# Altium schematic sheet LTC3811AM.SchDoc(LTC3811AM)
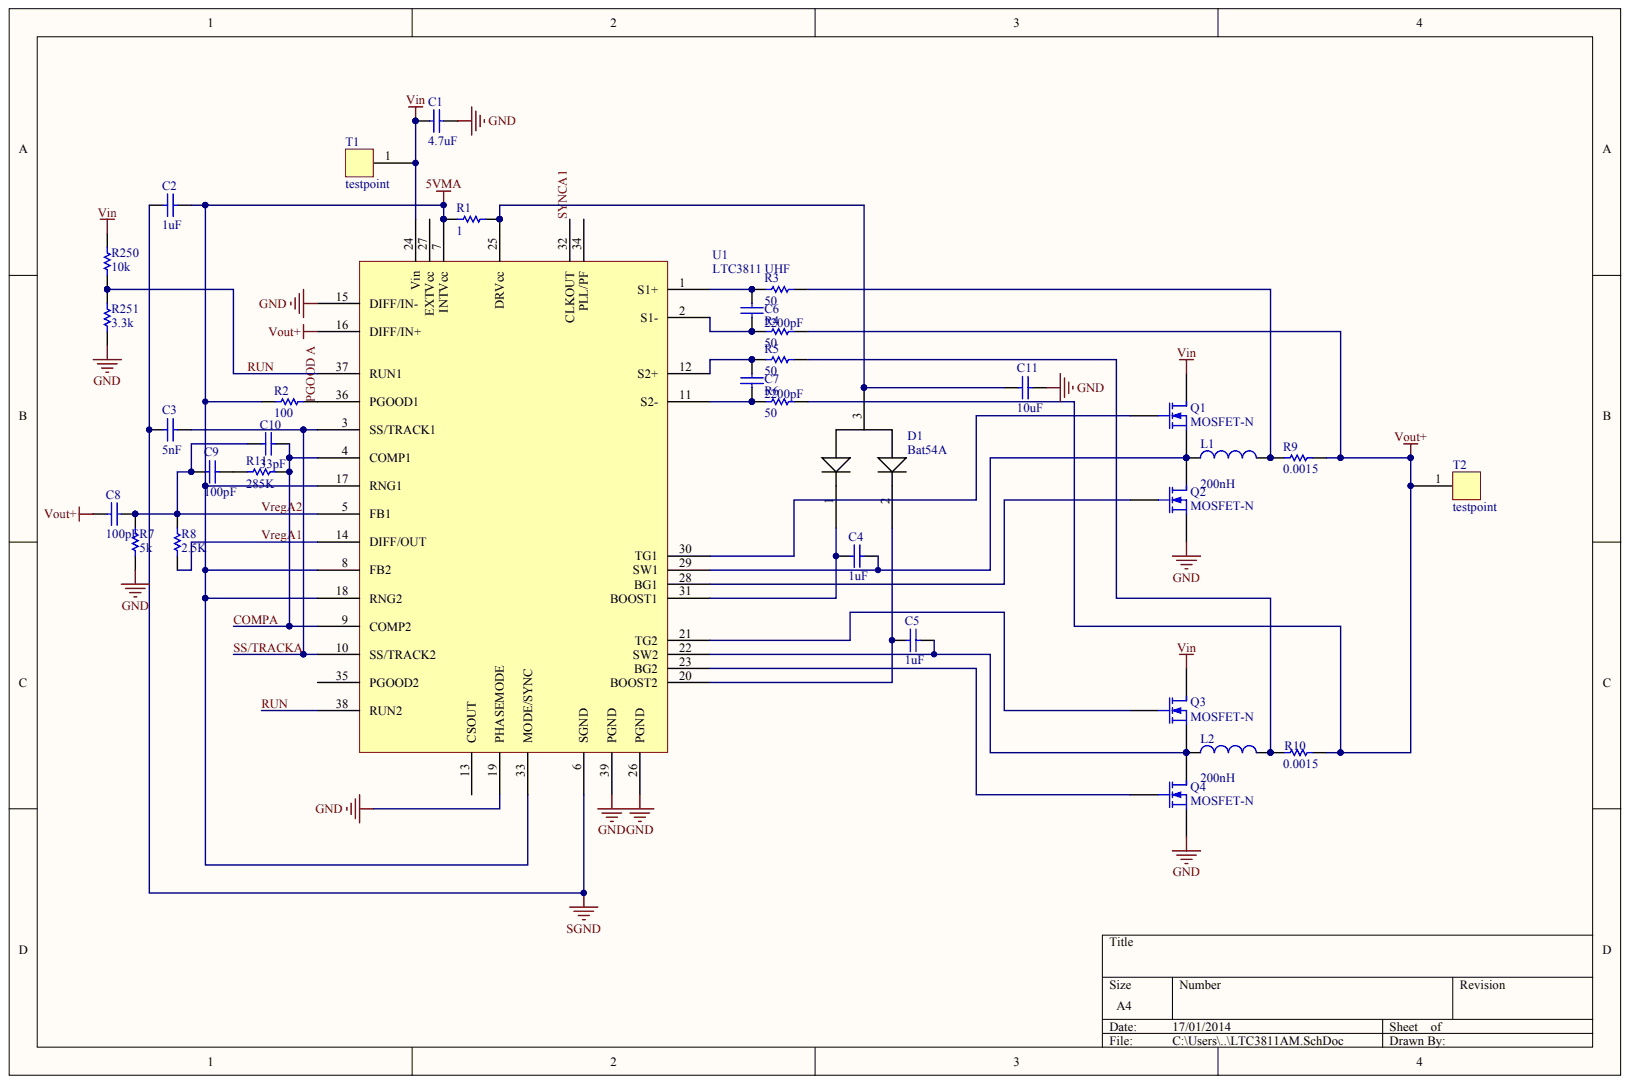
[diagram p0: LTC3811AM.SchDoc]

## Parts
C1  4.7uF
C2  1uF
C3  5nF
C4  1uF
C5  1uF
C6  2200pF
C7  2200pF
C8  100pF
C9  100pF
C10  33pF
C11  10uF
D1  Bat54A
L1  value 200nH
L2  value 200nH
Q1  MOSFET-N
Q2  MOSFET-N
Q3  MOSFET-N
Q4  MOSFET-N
R1  1
R2  100
R3  50
R4  50
R5  50
R6  50
R7  5k
R8  2.5K
R9  0.0015
R10  0.0015
R11  285K
R250  10k
R251  3.3k
T1  testpoint
T2  testpoint
U1  LTC3811 UHF

## Nets
5VMA: C2.1 R1.1 R2.1 U1.7(INTVcc) U1.8(FB2) U1.17(RNG1) U1.18(RNG2) U1.33(MODE/SYNC)
GND: C1.1 C11.2 Q2.3 Q4.3 R7.1 R251.1 U1.15(DIFF/IN-) U1.19(PHASEMODE) U1.26(PGND) U1.39(PGND)
SGND: C2.2 C3.2 U1.6(SGND)
VIN: C1.2 Q1.1 Q3.1 R250.2 T1.1 U1.24(Vin)
VOUT+: C8.2 R4.2 R6.2 R9.1 R10.1 T2.1 U1.16(DIFF/IN+)
COMPA: C10.1 R11.1 U1.4(COMP1) U1.9(COMP2)
PGOOD A: R2.2 U1.36(PGOOD1)
RUN: R250.1 R251.2 U1.37(RUN1) U1.38(RUN2)
SS/TRACKA: C3.1 U1.3(SS/TRACK1) U1.10(SS/TRACK2)
SYNCA1: U1.32(CLKOUT)
VREGA1: R8.1 U1.14(DIFF/OUT)
VREGA2: C8.1 C9.2 C10.2 R7.2 R8.2 U1.5(FB1)
NetC4_1: C4.1 D1.1 U1.31(BOOST1)
NetC4_2: C4.2 L1.1 Q1.3 Q2.1 U1.29(SW1)
NetC5_1: C5.1 L2.1 Q3.3 Q4.1 U1.22(SW2)
NetC5_2: C5.2 D1.2 U1.20(BOOST2)
NetC6_1: C6.1 R3.1 U1.1(S1+)
NetC6_2: C6.2 R4.1 U1.2(S1-)
NetC7_1: C7.1 R5.1 U1.12(S2+)
NetC7_2: C7.2 R6.1 U1.11(S2-)
NetC9_1: C9.1 R11.2
NetC11_1: C11.1 D1.3 R1.2 U1.25(DRVcc)
NetL1_2: L1.2 R3.2 R9.2
NetL2_2: L2.2 R5.2 R10.2
NetQ1_2: Q1.2 U1.30(TG1)
NetQ2_2: Q2.2 U1.28(BG1)
NetQ3_2: Q3.2 U1.21(TG2)
NetQ4_2: Q4.2 U1.23(BG2)

Not connected: U1 13(CSOUT) 27(EXTVcc) 34(PLL/PF) 35(PGOOD2)

## Library data of the parts
L1 L2 = (no symbol name): Code_IEC: 1005; Value: 200nH; Published: 8-Jun-2000; LatestRevisionDate: 29-May-2009; LatestRevisionNote: IPC-7351 Footprint Added.; PackageReference: 0402-A; PackageDescription: Chip Inductor; Code_JEITA: 0402; Publisher: Altium Limited
C1-C11 D1 Q1-Q4 R1-R11 R250 R251 T1 T2 U1 = (no symbol name)
  C1: Published: 8-Jun-2000; LatestRevisionDate: 17-Jul-2002; LatestRevisionNote: Re-released for DXP Platform.; PackageReference: RAD-0.3; PackageDescription: Radial Cap, Thru-Hole; 2 Leads; 0.3 in Pin Spacing; Value: 4.7uF
  C2 C4 C5: Published: 8-Jun-2000; LatestRevisionDate: 17-Jul-2002; LatestRevisionNote: Re-released for DXP Platform.; PackageReference: RAD-0.3; PackageDescription: Radial Cap, Thru-Hole; 2 Leads; 0.3 in Pin Spacing; Value: 1uF
  C3: Published: 8-Jun-2000; LatestRevisionDate: 17-Jul-2002; LatestRevisionNote: Re-released for DXP Platform.; PackageReference: RAD-0.3; PackageDescription: Radial Cap, Thru-Hole; 2 Leads; 0.3 in Pin Spacing; Value: 5nF
  C6 C7: Published: 8-Jun-2000; LatestRevisionDate: 17-Jul-2002; LatestRevisionNote: Re-released for DXP Platform.; PackageReference: RAD-0.3; PackageDescription: Radial Cap, Thru-Hole; 2 Leads; 0.3 in Pin Spacing; Value: 2200pF
  C8 C9: Published: 8-Jun-2000; LatestRevisionDate: 17-Jul-2002; LatestRevisionNote: Re-released for DXP Platform.; PackageReference: RAD-0.3; PackageDescription: Radial Cap, Thru-Hole; 2 Leads; 0.3 in Pin Spacing; Value: 100pF
  C10: Published: 8-Jun-2000; LatestRevisionDate: 17-Jul-2002; LatestRevisionNote: Re-released for DXP Platform.; PackageReference: RAD-0.3; PackageDescription: Radial Cap, Thru-Hole; 2 Leads; 0.3 in Pin Spacing; Value: 33pF
  C11: Published: 8-Jun-2000; LatestRevisionDate: 17-Jul-2002; LatestRevisionNote: Re-released for DXP Platform.; PackageReference: RAD-0.3; PackageDescription: Radial Cap, Thru-Hole; 2 Leads; 0.3 in Pin Spacing; Value: 10uF
  Q1-Q4: Published: 8-Jun-2000; LatestRevisionDate: 17-Jul-2002; LatestRevisionNote: Re-released for DXP Platform.; PackageVersion: 1995/1996; PackageReference: E3; PackageDescription: TO, Flat Index; 3 In-Line, Axial Leads; Body Dia. 4.6mm; Lead Dia. 0.5 mm (max)
  R1: Published: 8-Jun-2000; LatestRevisionDate: 17-Jul-2002; LatestRevisionNote: Re-released for DXP Platform.; PackageReference: AXIAL-0.3; PackageDescription: Axial Device, Thru-Hole; 2 Leads; 0.3 in Pin Spacing; Value: 1
  R2: Published: 8-Jun-2000; LatestRevisionDate: 17-Jul-2002; LatestRevisionNote: Re-released for DXP Platform.; PackageReference: AXIAL-0.3; PackageDescription: Axial Device, Thru-Hole; 2 Leads; 0.3 in Pin Spacing; Value: 100
  R3-R6: Published: 8-Jun-2000; LatestRevisionDate: 17-Jul-2002; LatestRevisionNote: Re-released for DXP Platform.; PackageReference: AXIAL-0.3; PackageDescription: Axial Device, Thru-Hole; 2 Leads; 0.3 in Pin Spacing; Value: 50
  R7: Published: 8-Jun-2000; LatestRevisionDate: 17-Jul-2002; LatestRevisionNote: Re-released for DXP Platform.; PackageReference: AXIAL-0.3; PackageDescription: Axial Device, Thru-Hole; 2 Leads; 0.3 in Pin Spacing; Value: 5k
  R8: Published: 8-Jun-2000; LatestRevisionDate: 17-Jul-2002; LatestRevisionNote: Re-released for DXP Platform.; PackageReference: AXIAL-0.3; PackageDescription: Axial Device, Thru-Hole; 2 Leads; 0.3 in Pin Spacing; Value: 2.5K
  R9 R10: Published: 8-Jun-2000; LatestRevisionDate: 17-Jul-2002; LatestRevisionNote: Re-released for DXP Platform.; PackageReference: AXIAL-0.3; PackageDescription: Axial Device, Thru-Hole; 2 Leads; 0.3 in Pin Spacing; Value: 0.0015
  R11: Published: 8-Jun-2000; LatestRevisionDate: 17-Jul-2002; LatestRevisionNote: Re-released for DXP Platform.; PackageReference: AXIAL-0.3; PackageDescription: Axial Device, Thru-Hole; 2 Leads; 0.3 in Pin Spacing; Value: 285K
  R250: Published: 8-Jun-2000; LatestRevisionDate: 17-Jul-2002; LatestRevisionNote: Re-released for DXP Platform.; PackageReference: AXIAL-0.3; PackageDescription: Axial Device, Thru-Hole; 2 Leads; 0.3 in Pin Spacing; Value: 10k
  R251: Published: 8-Jun-2000; LatestRevisionDate: 17-Jul-2002; LatestRevisionNote: Re-released for DXP Platform.; PackageReference: AXIAL-0.3; PackageDescription: Axial Device, Thru-Hole; 2 Leads; 0.3 in Pin Spacing; Value: 3.3k
  C1-C11 Q1-Q4 R1-R11 R250 R251: Publisher: Altium Limited
